# Altium schematic sheet Secure Gateway Teensy 4 Prototype.SchDoc("Secure Gateway Teensy 4 Prototype")
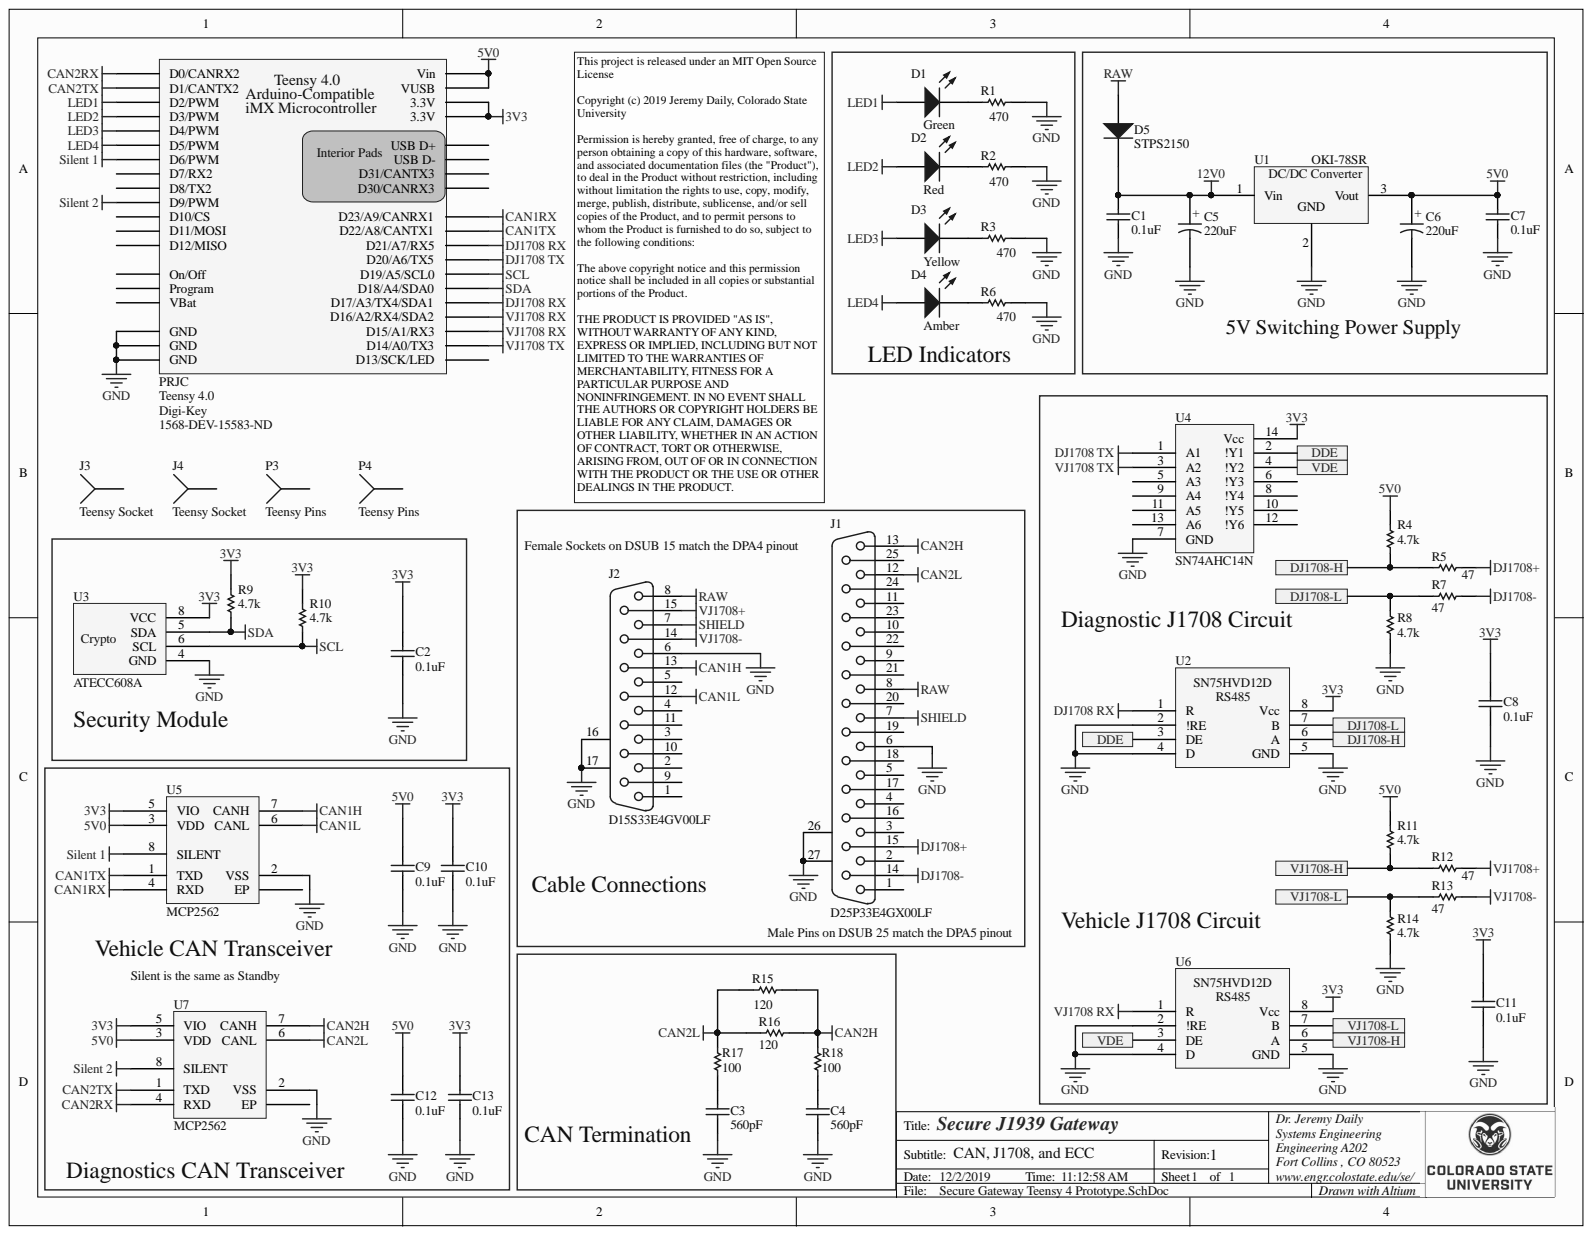
[diagram p0: Secure Gateway Teensy 4 Prototype.SchDoc]

## Parts
C1  0.1uF  [CAPR5-4X5]
C2  0.1uF  [CAPR5-4X5]
C3  560pF  [CAPR5-4X5]
C4  560pF  [CAPR5-4X5]
C5  220uF  [Elec. Cap 5 x 10mm]
C6  220uF  [Elec. Cap 5 x 10mm]
C7  0.1uF  [CAPR5-4X5]
C8  0.1uF  [CAPR5-4X5]
C9  0.1uF  [CAPR5-4X5]
C10  0.1uF  [CAPR5-4X5]
C11  0.1uF  [CAPR5-4X5]
C12  0.1uF  [CAPR5-4X5]
C13  0.1uF  [CAPR5-4X5]
D1  Green  [5mm Rad LED]
D2  Red  [5mm Rad LED]
D3  Yellow  [5mm Rad LED]
D4  Amber  [5mm Rad LED]
D5  STPS2150  [DO-204AL]
J1  D25P33E4GX00LF  [DSUB1.385-2H25A]
J2  D15S33E4GV00LF  [DSUB1.385-2H15]
J3  Teensy Socket
J4  Teensy Socket
P3  Teensy Pins
P4  Teensy Pins
R1  470  [AXIAL-0.4]
R2  470  [AXIAL-0.4]
R3  470  [AXIAL-0.4]
R4  4.7k  [AXIAL-0.4]
R5  47  [AXIAL-0.4]
R6  470  [AXIAL-0.4]
R7  47  [AXIAL-0.4]
R8  4.7k  [AXIAL-0.4]
R9  4.7k  [AXIAL-0.4]
R10  4.7k  [AXIAL-0.4]
R11  4.7k  [AXIAL-0.4]
R12  47  [AXIAL-0.4]
R13  47  [AXIAL-0.4]
R14  4.7k  [AXIAL-0.4]
R15  120  [AXIAL-0.4]
R16  120  [AXIAL-0.4]
R17  100  [AXIAL-0.4]
R18  100  [AXIAL-0.4]
Teensy_1  Teensy 4.0  Manufacturer: PRJC  [Teensy 4.0]
U1  OKI-78SR  [OKI-78SR]
U2  SN65HVD12P  [DIP-8]  (value not drawn)
U3  ATECC608A  [SOIC-8]
U4  SN74AHC14N  [DIP14]
U5  MCP2562  [DIP-8]
U6  SN65HVD12P  [DIP-8]  (value not drawn)
U7  MCP2562  [DIP-8]

## Ports
DDE, DJ1708-H, DJ1708-L, VDE, VJ1708-H, VJ1708-L

## Nets
3V3: C2.2 C8.2 C10.2 C11.2 C13.2 R9.1 R10.1 Teensy_1.3V3a(3.3V) Teensy_1.3V3b(3.3V) U2.8(Vcc) U3.8(VCC) U4.14(Vcc) U5.5(VIO) U6.8(Vcc) U7.5(VIO)
5V0: C6.1 C7.2 C9.2 C12.2 R4.1 R11.1 Teensy_1.Vin Teensy_1.VUSB U1.3 U5.3(VDD) U7.3(VDD)
12V0: C1.2 C5.1 D5.2 U1.1
CAN1H: J2.13 U5.7(CANH)
CAN1L: J2.12 U5.6(CANL)
CAN1RX: Teensy_1.23(D23/A9/CANRX1) U5.4(RXD)
CAN1TX: Teensy_1.22(D22/A8/CANTX1) U5.1(TXD)
CAN2H: J1.13 R15.2 R16.2 R18.2 U7.7(CANH)
CAN2L: J1.12 R15.1 R16.1 R17.2 U7.6(CANL)
CAN2RX: Teensy_1.0(D0/CANRX2) U7.4(RXD)
CAN2TX: Teensy_1.1(D1/CANTX2) U7.1(TXD)
DJ1708 RX: Teensy_1.17(D17/A3/TX4/SDA1) Teensy_1.21(D21/A7/RX5) U2.1(R)
DJ1708 TX: Teensy_1.20(D20/A6/TX5) U4.1(A1)
DJ1708+: J1.15 R5.2
DJ1708-: J1.14 R7.2
GND: C1.1 C2.1 C3.2 C4.2 C5.2 C6.2 C7.1 C8.1 C9.1 C10.1 C11.1 C12.1 C13.1 J1.6 J1.26 J1.27 J2.6 J2.16 J2.17 R1.2 R2.2 R3.2 R6.2 R8.2 R14.2 Teensy_1.G1(GND) Teensy_1.G2(GND) Teensy_1.G3(GND) U1.2 U2.2(!RE) U2.4(D) U2.5(GND) U3.4(GND) U4.7(GND) U5.2(VSS) U6.2(!RE) U6.4(D) U6.5(GND) U7.2(VSS)
LED1: D1.1 Teensy_1.2(D2/PWM)
LED2: D2.1 Teensy_1.3(D3/PWM)
LED3: D3.1 Teensy_1.4(D4/PWM)
LED4: D4.1 Teensy_1.5(D5/PWM)
RAW: D5.1 J1.8 J2.8
SCL: R10.2 Teensy_1.19(D19/A5/SCL0) U3.6(SCL)
SDA: R9.2 Teensy_1.18(D18/A4/SDA0) U3.5(SDA)
SHIELD: J1.7 J2.7
Silent 1: Teensy_1.6(D6/PWM) U5.8(SILENT)
Silent 2: Teensy_1.9(D9/PWM) U7.8(SILENT)
VJ1708 RX: Teensy_1.15(D15/A1/RX3) Teensy_1.16(D16/A2/RX4/SDA2) U6.1(R)
VJ1708 TX: Teensy_1.14(D14/A0/TX3) U4.3(A2)
VJ1708+: J2.15 R12.2
VJ1708-: J2.14 R13.2
DDE: U2.3(DE) U4.2(!Y1) ; port DDE
DJ1708-H: R4.2 R5.1 U2.6(A) ; port DJ1708-H
DJ1708-L: R7.1 R8.1 U2.7(B) ; port DJ1708-L
VDE: U4.4(!Y2) U6.3(DE) ; port VDE
VJ1708-H: R11.2 R12.1 U6.6(A) ; port VJ1708-H
VJ1708-L: R13.1 R14.1 U6.7(B) ; port VJ1708-L
NetC3_1: C3.1 R17.1
NetC4_1: C4.1 R18.1
NetD1_2: D1.2 R1.1
NetD2_2: D2.2 R2.1
NetD3_2: D3.2 R3.1
NetD4_2: D4.2 R6.1

Not connected: J1 1 2 3 4 5 9 10 11 16 17 18 19 20 21 22 23 24 25; J2 1 2 3 4 5 9 10 11; J3 1; J4 1; P3 1; P4 1; Teensy_1 7(D7/RX2) 8(D8/TX2) 10(D10/CS) 11(D11/MOSI) 12(D12/MISO) 13(D13/SCK/LED) CRX3(D30/CANRX3) CTX3(D31/CANTX3) D+(USB_D+) D- On(On/Off) P(Program) VBat; U4 5(A3) 6(!Y3) 8(!Y4) 9(A4) 10(!Y5) 11(A5) 12(!Y6) 13(A6); U5 0(EP); U7 0(EP)

## Library data of the parts
C1 C2 C7-C13 = Cap: 0.1µF ±10% 50V Ceramic Capacitor X7R Radial; PartNumber: FA28X7R1H104KNU00; Manufacturer: TDK Corporation; Alternative: Acceptable; Supplier Part Number 1: 445-175529-ND; Supplier 1: Digi-Key; Simulation: CAP; Signal Integrity: Cap; Component Kind: Standard
  C1 C7: Library Name: SSS Schematic Library.SchLib
  C2 C8-C13: Library Name: SSS Integrated Library.IntLib
C3 C4 = Cap: 560pF ±5% 100V Ceramic Capacitor C0G, NP0 Radial; PartNumber: FA28C0G2A561JNU00; Manufacturer: TDK Corporation; Alternative: Acceptable; Supplier Part Number 1: 445-175528-ND; Supplier 1: Digi-Key; Simulation: CAP; Signal Integrity: Cap; Library Name: SSS Integrated Library.IntLib; Component Kind: Standard
C5 C6 = Electrolytic Cap: 220µF 50V Aluminum Electrolytic Capacitors Radial, Can 175 mOhm @ 10kHz 5000 Hrs @ 105°C; PartNumber: B41858C6227M000; Manufacturer: EPCOS (TDK); Supplier Part Number 1: 495-6000-ND; Supplier 1: Digi-Key; Library Name: SSS Integrated Library.IntLib; Component Kind: Standard
D1 = LED - SMD: Green 569nm LED Indication - Discrete 2.1V Radial; Manufacturer: Lite-On Inc.; Supplier Part Number 1: 160-1130-ND; Supplier 1: Digi-Key; PartNumber: LTL-4233; Library Name: SSS Integrated Library.IntLib; Component Kind: Standard
D2 = LED - SMD: Red 623nm LED Indication - Discrete 2V Radial; Manufacturer: Lite-On Inc.; Supplier Part Number 1: 160-1127-ND; Supplier 1: Digi-Key; PartNumber: LTL-4223; Library Name: SSS Integrated Library.IntLib; Component Kind: Standard
D3 = LED - SMD: Yellow 588nm LED Indication - Discrete 2.1V Radial; Manufacturer: Lite-On Inc.; Supplier Part Number 1: 160-1133-ND; Supplier 1: Digi-Key; PartNumber: LTL-4253; Library Name: SSS Integrated Library.IntLib; Component Kind: Standard
D4 = LED - SMD: Amber 588nm LED Indication - Discrete 2.1V Radial; Manufacturer: Lite-On Inc.; Supplier Part Number 1: 160-1966-ND; Supplier 1: Digi-Key; PartNumber: LTL-4273; Library Name: SSS Integrated Library.IntLib; Component Kind: Standard
D5 = Diode 1N4003: Diode Schottky 150V 2A Through Hole DO-15; Manufacturer: STMicroelectronics; Supplier Part Number 1: 497-3213-1-ND; Supplier 1: Digi-Key; Simulation: 1N4003; Signal Integrity: 1N4003; PartNumber: STPS2150; Library Name: Miscellaneous Devices.IntLib; Component Kind: Standard
J1 = D Connector 25: Receptacle Assembly, 25 Position, Right Angle; Manufacturer: Amphenol ICC (FCI); Supplier Part Number 1: 609-1505-ND; Supplier 1: Digi-Key; Signal Integrity: Connector; PartNumber: D25P33E4GX00LF; Library Name: Miscellaneous Connectors.IntLib; Component Kind: Standard
J2 = D Connector 15: Receptacle Assembly, 15 Position, Right Angle; Manufacturer: Amphenol ICC (FCI); Supplier Part Number 1: 609-1498-ND; Supplier 1: Digi-Key; Signal Integrity: Connector; PartNumber: D15S33E4GV00LF; Library Name: Miscellaneous Connectors.IntLib; Component Kind: Standard
J3 J4 = Socket: 14 Position Header Connector  Through Hole; PartNumber: PPTC141LFBN-RC; Manufacturer: Sullins Connector Solutions; Supplier Part Number 1: S7012-ND; Supplier 1: Digi-Key; Signal Integrity: Connector; Library Name: Miscellaneous Connectors.IntLib; Component Kind: Standard
P3 P4 = Socket: Connector Header Through Hole 14 position 0.100" (2.54mm); PartNumber: PREC014SAAN-RC; Manufacturer: Sullins Connector Solutions; Supplier Part Number 1: S1012EC-14-ND; Supplier 1: Digi-Key; Signal Integrity: Connector; Library Name: Miscellaneous Connectors.IntLib; Component Kind: Standard
R1-R3 R6 = Chip Resistor: 330 Ohms ±1% 0.1W, 1/10W Chip Resistor 0603 (1608 Metric) Automotive AEC-Q200, High Voltage Thick Film; PartNumber: CF14JT470R; Manufacturer: Stackpole Electronics Inc; Alternative: Acceptable; Supplier Part Number 1: CF14JT470RCT-ND; Supplier 1: Digi-Key; Library Name: SSS Integrated Library.IntLib; Component Kind: Standard
R4 R8-R11 R14 = Chip Resistor: 4.7 kOhms ±5% 0.25W, 1/4W Through Hole Resistor Axial Flame Retardant Coating, Safety Carbon Film; PartNumber: CF14JT4K70; Manufacturer: Stackpole Electronics Inc; Alternative: Acceptable; Supplier Part Number 1: CF14JT4K70CT-ND; Supplier 1: Digi-Key; Library Name: SSS Integrated Library.IntLib; Component Kind: Standard
R5 R7 R12 R13 = Chip Resistor: 47 Ohms ±5% 0.25W, 1/4W Through Hole Resistor Axial Flame Retardant Coating, Safety Carbon Film; PartNumber: CF14JT47R0; Manufacturer: Stackpole Electronics Inc; Supplier Part Number 1: CF14JT47R0CT-ND; Supplier 1: Digi-Key; Library Name: SSS Integrated Library.IntLib; Component Kind: Standard
R15 R16 = Chip Resistor: 120 Ohms ±5% 0.25W, 1/4W Through Hole Resistor Axial Flame Retardant Coating, Safety Carbon Film; PartNumber: CF14JT120RCT-ND; Manufacturer: Stackpole Electronics Inc; Alternative: Acceptable; Supplier Part Number 1: CF14JT120RCT-ND; Supplier 1: Digi-Key; Library Name: SSS Integrated Library.IntLib; Component Kind: Standard
R17 R18 = Chip Resistor: 100 Ohms ±5% 0.25W, 1/4W Through Hole Resistor Axial Flame Retardant Coating, Safety Carbon Film; PartNumber: CF14JT100R; Manufacturer: Stackpole Electronics Inc; Alternative: Acceptable; Supplier Part Number 1: CF14JT100RCT-ND; Supplier 1: Digi-Key; Library Name: SSS Integrated Library.IntLib; Component Kind: Standard
Teensy_1 = Teensy_4.0: 32 Bit Arduino-Compatible iMX Microcontroller; Supplier Part Number 1: 1568-DEV-15583-ND; Supplier 1: Digi-Key; PartNumber: Teensy 4.0; Library Name: Teensy.IntLib; Component Kind: Standard
U1 = 7805: 5V DC/DC Converter (7805 replacement); PartNumber: OKI-78SR-5/1.5-W36H-C; Manufacturer: Murata Power Solutions Inc.; Supplier Part Number 1: 811-2692-ND; Supplier 1: Digi-Key; Library Name: SSS Integrated Library.IntLib; Component Kind: Standard
U2 U6 = SN75HVD12D (3.3v RS485): 1/1 Transceiver Half RS422, RS485 8-PDIP; Manufacturer: Texas Instruments; Supplier Part Number 1: 296-13862-5-ND; Supplier 1: Digi-Key; PartNumber: SN65HVD12P; Library Name: SSS Integrated Library.IntLib; Component Kind: Standard
U3 = ATECC508A: Authentication Chip IC Networking and Communications 8-SOIC; PartNumber: ATECC608A-SSHDA-T; Manufacturer: Microchip Technology; Supplier Part Number 1: ATECC608A-SSHDA-TCT-ND; Supplier 1: Digi-Key; Library Name: TU Custom Parts Schematics.SchLib; Component Kind: Standard
U4 = 74VHC14 Hex Inverter: Inverter IC 6 Channel Schmitt Trigger 14-PDIP; Manufacturer: Texas Instruments; Supplier Part Number 1: 296-4550-5-ND; Supplier 1: Digi-Key; PartNumber: SN74AHC14N; Library Name: SSS Integrated Library.IntLib; Component Kind: Standard
U5 U7 = MCP2558FD: Microchip CAN FD Transceiver with Silent Mode; PartNumber: MCP2562-E/P; Supplier Part Number 1: MCP2562-E/P-ND; Supplier 1: Digi-Key; Manufacturer: Microchip; Library Name: TU Custom Parts Schematics.SchLib; Component Kind: Standard
